# Altium schematic sheet coilcube_frontend_v2.SchDoc
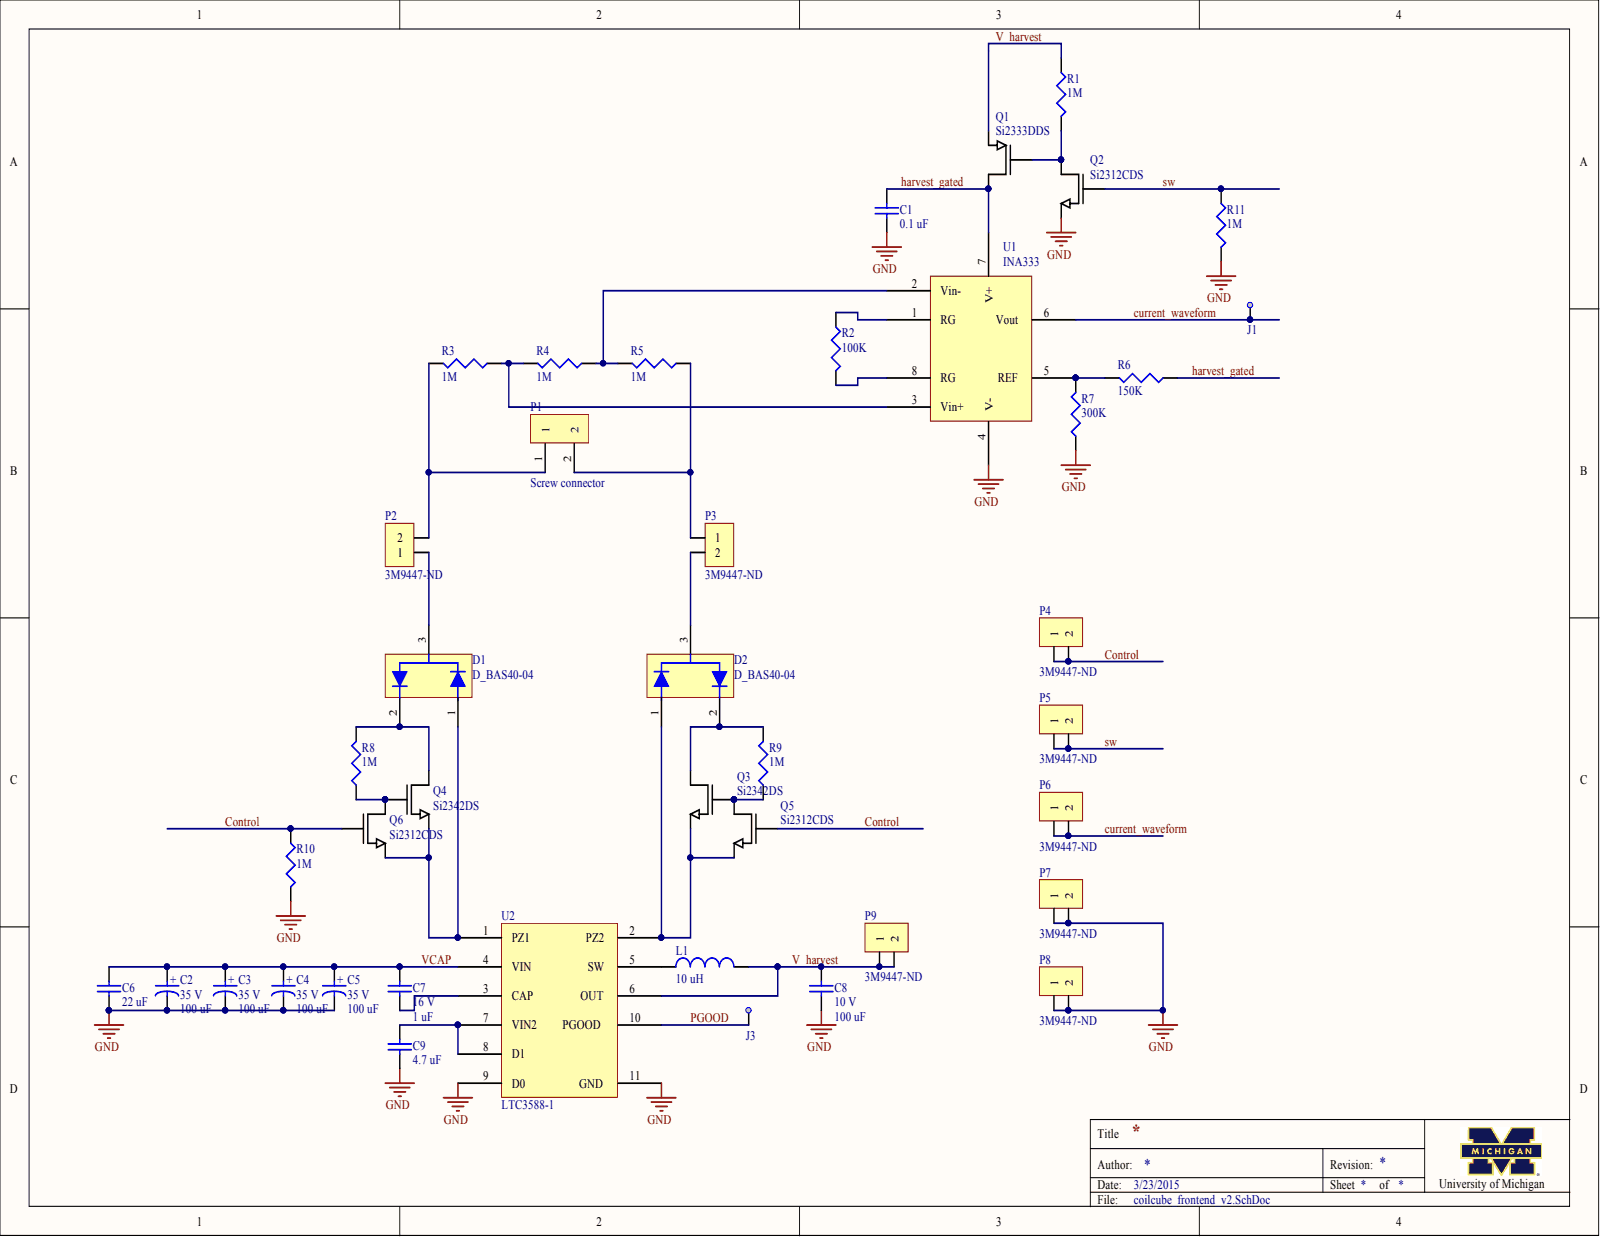
[diagram p0: coilcube_frontend_v2.SchDoc]

## Parts
C1  value 0.1 uF  [0603]  (hidden comment: Ceramic capacitor)
C2  value 100 uF  voltage rated: 35 V  [KEMET_EDK_9H]  (hidden comment: Aluminum capacitor)
C3  value 100 uF  voltage rated: 35 V  [KEMET_EDK_9H]  (hidden comment: Aluminum capacitor)
C4  value 100 uF  voltage rated: 35 V  [KEMET_EDK_9H]  (hidden comment: Aluminum capacitor)
C5  value 100 uF  voltage rated: 35 V  [KEMET_EDK_9H]  (hidden comment: Aluminum capacitor)
C6  value 22 uF  [1206]  (hidden comment: Ceramic capacitor)
C7  value 1 uF  voltage rated: 16 V  [0603]  (hidden comment: Ceramic capacitor)
C8  value 100 uF  voltage rated: 10 V  [1210]  (hidden comment: Ceramic capacitor)
C9  value 4.7 uF  [0603]  (hidden comment: Ceramic capacitor)
D1  D_BAS40-04  [SOT23-3]
D2  D_BAS40-04  [SOT23-3]
J1  5004  [TestPoint]  (value not drawn)
J3  5004  [TestPoint]  (value not drawn)
L1  value 10 uH  [CDRH2D18]  (hidden comment: Chip inductor)
P1  Screw connector  [MPT_2position]
P2  Supplier Part Number 1: 3M9447-ND  [1x2 header]  (hidden comment: 1 x 2 header)
P3  Supplier Part Number 1: 3M9447-ND  [1x2 header]  (hidden comment: 1 x 2 header)
P4  Supplier Part Number 1: 3M9447-ND  [1x2 header]  (hidden comment: 1 x 2 header)
P5  Supplier Part Number 1: 3M9447-ND  [1x2 header]  (hidden comment: 1 x 2 header)
P6  Supplier Part Number 1: 3M9447-ND  [1x2 header]  (hidden comment: 1 x 2 header)
P7  Supplier Part Number 1: 3M9447-ND  [1x2 header]  (hidden comment: 1 x 2 header)
P8  Supplier Part Number 1: 3M9447-ND  [1x2 header]  (hidden comment: 1 x 2 header)
P9  Supplier Part Number 1: 3M9447-ND  [1x2 header]  (hidden comment: 1 x 2 header)
Q1  Si2333DDS  [SOT23-3]
Q2  Si2312CDS  [SOT23-3]
Q3  Si2342DS  [SOT23-3]
Q4  Si2342DS  [SOT23-3]
Q5  Si2312CDS  [SOT23-3]
Q6  Si2312CDS  [SOT23-3]
R1  value 1M  [0603]  (hidden comment: Chip resistor)
R2  value 100K  [0603]  (hidden comment: Chip resistor)
R3  value 1M  [0603]  (hidden comment: Chip resistor)
R4  value 1M  [0603]  (hidden comment: Chip resistor)
R5  value 1M  [0603]  (hidden comment: Chip resistor)
R6  value 150K  [0603]  (hidden comment: Chip resistor)
R7  value 300K  [0603]  (hidden comment: Chip resistor)
R8  value 1M  [0603]  (hidden comment: Chip resistor)
R9  value 1M  [0603]  (hidden comment: Chip resistor)
R10  value 1M  [0603]  (hidden comment: Chip resistor)
R11  value 1M  [0603]  (hidden comment: Chip resistor)
U1  INA333  [MSOP-8]
U2  LTC3588-1  [TSSOP50P490X110_HS-11N]

## Nets
GND: C1.2 C2.2 C3.2 C4.2 C5.2 C6.2 C8.2 C9.2 P7.1 P7.2 P8.1 P8.2 Q2.2 R7.2 R10.1 R11.1 U1.4(V-) U2.9(D0) U2.11(GND)
CONTROL: P4.1 P4.2 Q5.1 Q6.1 R10.2
CURRENT_WAVEFORM: J1.1 P6.1 P6.2 U1.6(Vout)
HARVEST_GATED: C1.1 Q1.3 R6.2 U1.7(V+)
PGOOD: J3.1 U2.10(PGOOD)
SW: P5.1 P5.2 Q2.1 R11.2
V_HARVEST: C8.1 L1.1 P9.1 P9.2 Q1.2 R1.2 U2.6(OUT)
VCAP: C2.1 C3.1 C4.1 C5.1 C6.1 C7.1 U2.4(VIN)
NetC7_2: C7.2 U2.3(CAP)
NetC9_1: C9.1 U2.7(VIN2) U2.8(D1)
NetD1_1: D1.1 Q4.2 Q6.2 U2.1(PZ1)
NetD1_2: D1.2 Q4.3 R8.1
NetD1_3: D1.3 P2.1
NetD2_1: D2.1 Q3.2 Q5.2 U2.2(PZ2)
NetD2_2: D2.2 Q3.3 R9.1
NetD2_3: D2.3 P3.2
NetL1_2: L1.2 U2.5(SW)
NetP1_1: P1.1 P2.2 R3.1
NetP1_2: P1.2 P3.1 R5.2
NetQ1_1: Q1.1 Q2.3 R1.1
NetQ3_1: Q3.1 Q5.3 R9.2
NetQ4_1: Q4.1 Q6.3 R8.2
NetR2_1: R2.1 U1.8(RG)
NetR2_2: R2.2 U1.1(RG)
NetR3_2: R3.2 R4.1 U1.3(Vin+)
NetR4_2: R4.2 R5.1 U1.2(Vin-)
NetR6_1: R6.1 R7.1 U1.5(REF)

## Library data of the parts
C1 = C_0.1u_25V_0603_ceramic: Ceramic capacitor, 0.1 uF, 0603 package; Manufacture: TDK; tolerance: 10%; Value: 0.1 uF; voltage rated: 25V; Component Kind: Standard; Library Name: rlc.SchLib; package: 0603; Supplier 1: Digi-Key; Supplier Part Number 1: 445-1316-1-ND
C2-C5 = C_100u_35V_aluminum: Aluminum capacitor, 100 uF, 35 V, KEMET EDK 9H; tolerance: 20%; Component Kind: Standard; Library Name: rlc.SchLib; Manufacture: Kemet; Supplier 1: Digi-Key; Supplier Part Number 1: 399-6671-1-ND
C6 = C_22u_35V_1206_ceramic: Ceramic capacitor, 22 uF, 35 V, 1206 package; Manufacture: TDK; tolerance: 20%; voltage rated: 35 V; Component Kind: Standard; Library Name: rlc.SchLib; package: 1206; Supplier 1: Digi-Key; Supplier Part Number 1: 445-8045-1-ND
C7 = C_1u_16V_0603_ceramic: Ceramic capacitor, 1 uF, 16 V, 0603 package; Manufacture: TDK; tolerance: 10%; Component Kind: Standard; Library Name: rlc.SchLib; package: 0603; Supplier 1: Digi-Key; Supplier Part Number 1: 445-1604-1-ND
C8 = C_100u_10V_1210_ceramic: Ceramic capacitor, 100u, 1210 package; Manufacture: Samsung; tolerance: 20%; Component Kind: Standard; Library Name: rlc.SchLib; package: 2917; Supplier 1: Digi-Key; Supplier Part Number 1: 1276-3364-1-ND
C9 = C_4.7u_10V_0603_ceramic: Ceramic capacitor, 4.7u, 10V, 0603 package; Manufacture: TDK; Temp rating: X5R; tolerance: 20%; Component Kind: Standard; Library Name: rlc.SchLib; package: 0603; Supplier 1: Digi-Key; Supplier Part Number 1: 445-7482-1-ND; voltage rated: 10 V
D1 D2 = D_BAS40-04: SURFACE MOUNT SCHOTTKY BARRIER DIODE; Component Kind: Standard; Library Name: rlc.SchLib; Supplier 1: Digi-Key; Supplier Part Number 1: BAS40-04FDICT-ND
J1 J3 = TestPoint: TEST POINT PC MINI .040"D YELLOW; Component Kind: Standard; datasheet: http://mail.keyelco.com/ENG._DEPT/PDF%20CAT%20NO%20Drawings/5004.pdf; Supplier 1: Digi-Key; Supplier Part Number 1: 5004K-ND; Library Name: coilcube_frontend.SchLib
L1 = L_10uH_1A_180m: Chip inductor, 10 uH,  1A, 180 mOhm; tolerance: 30%; Component Kind: Standard; Library Name: rlc.SchLib; Supplier 1: Digi-Key; Supplier Part Number 1: 308-1959-1-ND
P1 = MPT_2POS: Screw connector 2 positions; Component Kind: Standard; Library Name: connectors.SchLib; Supplier 1: Digi-Key; Supplier Part Number 1: 277-1273-ND
P2-P9 = 1 x 2 header: 100 mils standard header; Component Kind: Standard; Library Name: connectors.SchLib; Supplier 1: Digi-Key
Q1 = Si2333DDS: P-Channel 12 V (D-S) MOSFET; Component Kind: Standard; Library Name: transistors.SchLib; Supplier 1: Digi-Key; Supplier Part Number 1: SI2333DDS-T1-GE3CT-ND
Q2 Q5 Q6 = Si2312CDS: N-Channel 20 V (D-S) MOSFET; Component Kind: Standard; Library Name: transistors.SchLib; Supplier 1: Digi-Key; Supplier Part Number 1: SI2312CDS-T1-GE3CT-ND
Q3 Q4 = Si2342DS: N-Channel 8 V (D-S) MOSFET; Component Kind: Standard; Library Name: transistors.SchLib; Supplier 1: Digi-Key; Supplier Part Number 1: SI2342DS-T1-GE3-ND
R1 R3-R5 R8-R11 = R_1M_0603: Chip resistor, 1 Meg, 0603 package; power: 1/10 W; tolerance: 1%; Component Kind: Standard; Library Name: rlc.SchLib; package: 0603; Supplier 1: Digi-Key; Supplier Part Number 1: 541-1.00MHCT-ND
R2 = R_100K_0603: Chip resistor, 100K, 0603 package; power: 1/10 W; tolerance: 1%; Component Kind: Standard; Library Name: rlc.SchLib; package: 0603; Supplier 1: Digi-Key; Supplier Part Number 1: 541-100KHCT-ND
R6 = R_150K_0603: Chip resistor, 150K, 0603 package; power: 1/10 W; tolerance: 1%; Component Kind: Standard; Library Name: rlc.SchLib; package: 0603; Supplier 1: Digi-Key; Supplier Part Number 1: 541-150KHCT-ND
R7 = R_300K_0603: Chip resistor, 300K, 0603 package; power: 1/10 W; tolerance: 1%; Component Kind: Standard; Library Name: rlc.SchLib; package: 0603; Supplier 1: Digi-Key; Supplier Part Number 1: 541-300KHCT-ND
U1 = INA333: Micro-Power (50µA), Zerø-Drift, Rail-to-Rail Out  Instrumentation Amplifier; Component Kind: Standard; Library Name: amplifier.SchLib; Supplier 1: Digi-Key; Supplier Part Number 1: 296-23564-1-ND
U2 = LTC3588-1: Piezoelectric Energy   Harvesting Power Supply; Component Kind: Standard; Supplier 1: Digi-Key; Supplier Part Number 1: LTC3588EMSE-1#PBF-ND; Library Name: coilcube_frontend.SchLib
